# Altium schematic sheet Schematic4_.SchDoc(Schematic4_)
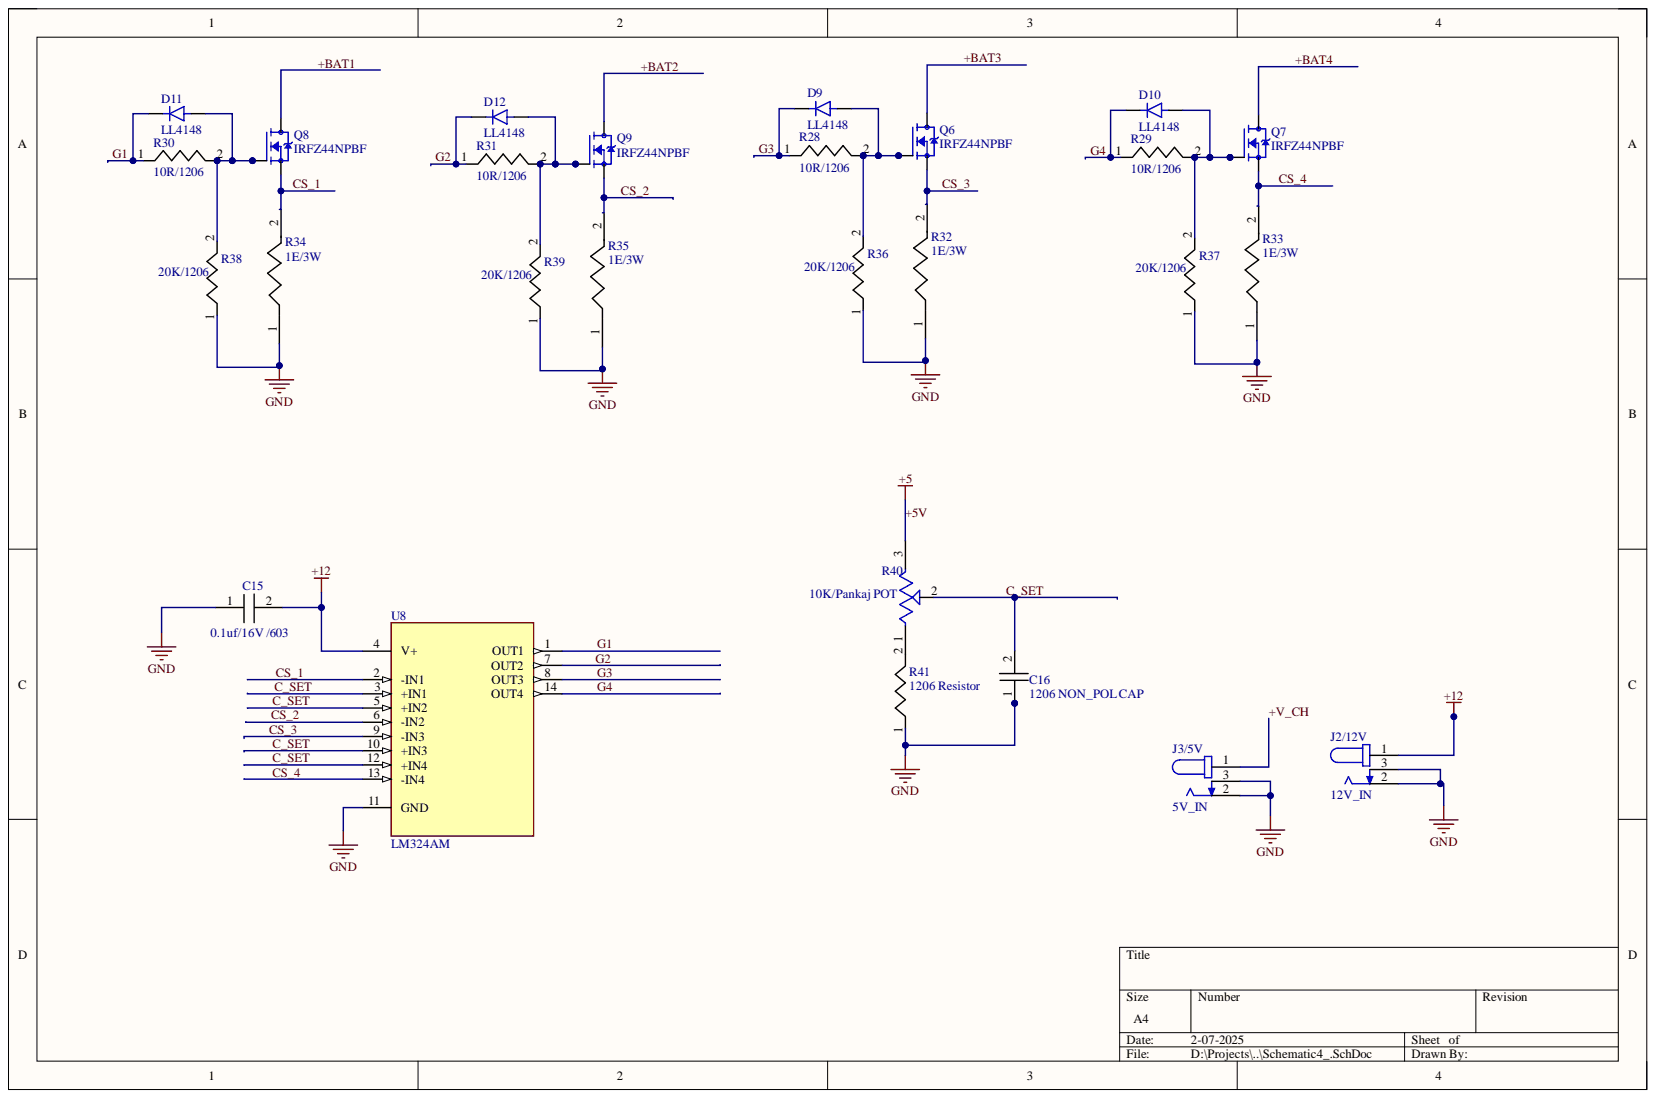
[diagram p0: Schematic4_.SchDoc]

## Parts
C15  0.1uf/16V /603  [603]
C16  1206 NON_POL CAP  [1206]
D9  LL4148  [DIO_LL4148]
D10  LL4148  [DIO_LL4148]
D11  LL4148  [DIO_LL4148]
D12  LL4148  [DIO_LL4148]
J2/12V  12V_IN  [CUI_PJ-002A]
J3/5V  5V_IN  [CUI_PJ-002A]
Q6  IRFZ44NPBF  [TO254P1016X419X2286-3]
Q7  IRFZ44NPBF  [TO254P1016X419X2286-3]
Q8  IRFZ44NPBF  [TO254P1016X419X2286-3]
Q9  IRFZ44NPBF  [TO254P1016X419X2286-3]
R28  10R/1206  [1206]
R29  10R/1206  [1206]
R30  10R/1206  [1206]
R31  10R/1206  [1206]
R32  1E/3W  [1W Resistor]
R33  1E/3W  [1W Resistor]
R34  1E/3W  [1W Resistor]
R35  1E/3W  [1W Resistor]
R36  20K/1206  [1206]
R37  20K/1206  [1206]
R38  20K/1206  [1206]
R39  20K/1206  [1206]
R40  10K/Pankaj POT  [3590P]
R41  1206 Resistor  [1206]
U8  LM324AM  [SOIC127P620X175-14N]

## Nets
+12: C15.2 J2/12V.1 U8.4(V+)
GND: C15.1 C16.1 J2/12V.2 J2/12V.3 J3/5V.2 J3/5V.3 R32.1 R33.1 R34.1 R35.1 R36.1 R37.1 R38.1 R39.1 R41.1 U8.11(GND)
+5V: R40.3
+BAT1: Q8.2
+BAT2: Q9.2
+BAT3: Q6.2
+BAT4: Q7.2
+V_CH: J3/5V.1
C_SET: C16.2 R40.2 U8.3(+IN1) U8.5(+IN2) U8.10(+IN3) U8.12(+IN4)
CS_1: Q8.3 R34.2 U8.2(-IN1)
CS_2: Q9.3 R35.2 U8.6(-IN2)
CS_3: Q6.3 R32.2 U8.9(-IN3)
CS_4: Q7.3 R33.2 U8.13(-IN4)
G1: D11.C R30.1 U8.1(OUT1)
G2: D12.C R31.1 U8.7(OUT2)
G3: D9.C R28.1 U8.8(OUT3)
G4: D10.C R29.1 U8.14(OUT4)
NetD9_A: D9.A Q6.1 R28.2 R36.2
NetD10_A: D10.A Q7.1 R29.2 R37.2
NetD11_A: D11.A Q8.1 R30.2 R38.2
NetD12_A: D12.A Q9.1 R31.2 R39.2
NetR40_1: R40.1 R41.2

## Library data of the parts
C15 = 603 SMD CAP: Component Kind: Standard; Library Name: Custom1.IntLib
C16 = 1206 NON-POL CAP: Component Kind: Standard; Library Name: Custom1.IntLib
D9-D12 = LL4148: Diode Small Signal Switching 100V 0.15A Automotive 2-Pin Mini-MELF T/R; MF: Diotec Semiconductor; Availability: In Stock; Check_prices: https://www.snapeda.com/parts/LL4148/Diotec/view-part/?ref=eda; Component Kind: Standard; Library Name: LL4148.IntLib; MP: LL4148; Package: MINIMELF-2 Diotec; Price: None; Purchase-URL: https://pricing.snapeda.com/search/part/LL4148/?ref=eda; SnapEDA_Link: https://www.snapeda.com/parts/LL4148/Diotec/view-part/?ref=snap
J2/12V J3/5V = PJ-002A: 2.0 x 6.5 mm, 2.5 A, Horizontal, Through Hole, Dc Power Jack Connector; MF: CUI Devices; Availability: In Stock; Check_prices: https://www.snapeda.com/parts/PJ-002A/CUI+Devices/view-part/?ref=eda; Component Kind: Standard; CUI_purchase_URL: https://www.cuidevices.com/product/interconnect/connectors/dc-power-connectors/jacks/pj-002a?utm_source=snapeda.com&utm_medium=referral&utm_campaign=snapedaBOM; Library Name: PJ-002A.IntLib; MP: PJ-002A; Package: None; Price: None; Purchase-URL: https://pricing.snapeda.com/search/part/PJ-002A/?ref=eda; SnapEDA_Link: https://www.snapeda.com/parts/PJ-002A/CUI+Devices/view-part/?ref=snap
Q6-Q9 = IRFZ44NPBF: N-Channel 55 V 49A (Tc) 94W (Tc) Through Hole TO-220AB; MF: Infineon; Check_prices: https://www.snapeda.com/parts/IRFZ44NPBF/Infineon+Technologies/view-part/?ref=eda; Component Kind: Standard; Digi-Key_Part_Number: IRFZ44NPBF-ND; Library Name: IRFZ44NPBF.IntLib; MP: IRFZ44NPBF; Package: TO-220-3 Infineon; Purchase-URL: https://pricing.snapeda.com/search/part/IRFZ44NPBF/?ref=eda; SnapEDA_Link: https://www.snapeda.com/parts/IRFZ44NPBF/Infineon+Technologies/view-part/?ref=snap
R28-R31 R36-R39 = 1206 Resistor: Component Kind: Standard; Library Name: Custom1.IntLib
R32-R35 = 1W carbon Resistor: Component Kind: Standard; Library Name: Custom1.IntLib
R40 = 3590P-1-103L: Res Wirewound POT 10K Ohm 5% 2W 10(Elec)/10(Mech)Turn 6.3mm (39.22 X 22.23 X 22.92mm) Pin Panel Mount/Through Hole; MF: Bourns; Availability: In Stock; Check_prices: https://www.snapeda.com/parts/3590P-1-103L/Bourns/view-part/291348/?ref=eda; Component Kind: Standard; Library Name: 3590P-1-103L.IntLib; MP: 3590P-1-103L; Package: None; Price: None; SnapEDA_Link: https://www.snapeda.com/parts/3590P-1-103L/Bourns/view-part/291348/?ref=snap
U8 = LM324AM: General Purpose Amplifier 4 Circuit 14-SOIC; MF: Texas Instruments; MP: LM324AM/NOPB; Availability: Unavailable; Component Kind: Standard; Library Name: LM324AM.IntLib; Package: SOIC-14 Texas Instruments; Price: None; SnapEDA_Link: https://www.snapeda.com/parts/LM324AM/NOPB/Texas%20Instruments/view-part/187007/?ref=snap
R41 = 1206 Resistor: Component Kind: Standard; Library Name: Custom1.IntLib
